# Altium schematic sheet Common_Conn.SchDoc(Common_Conn)
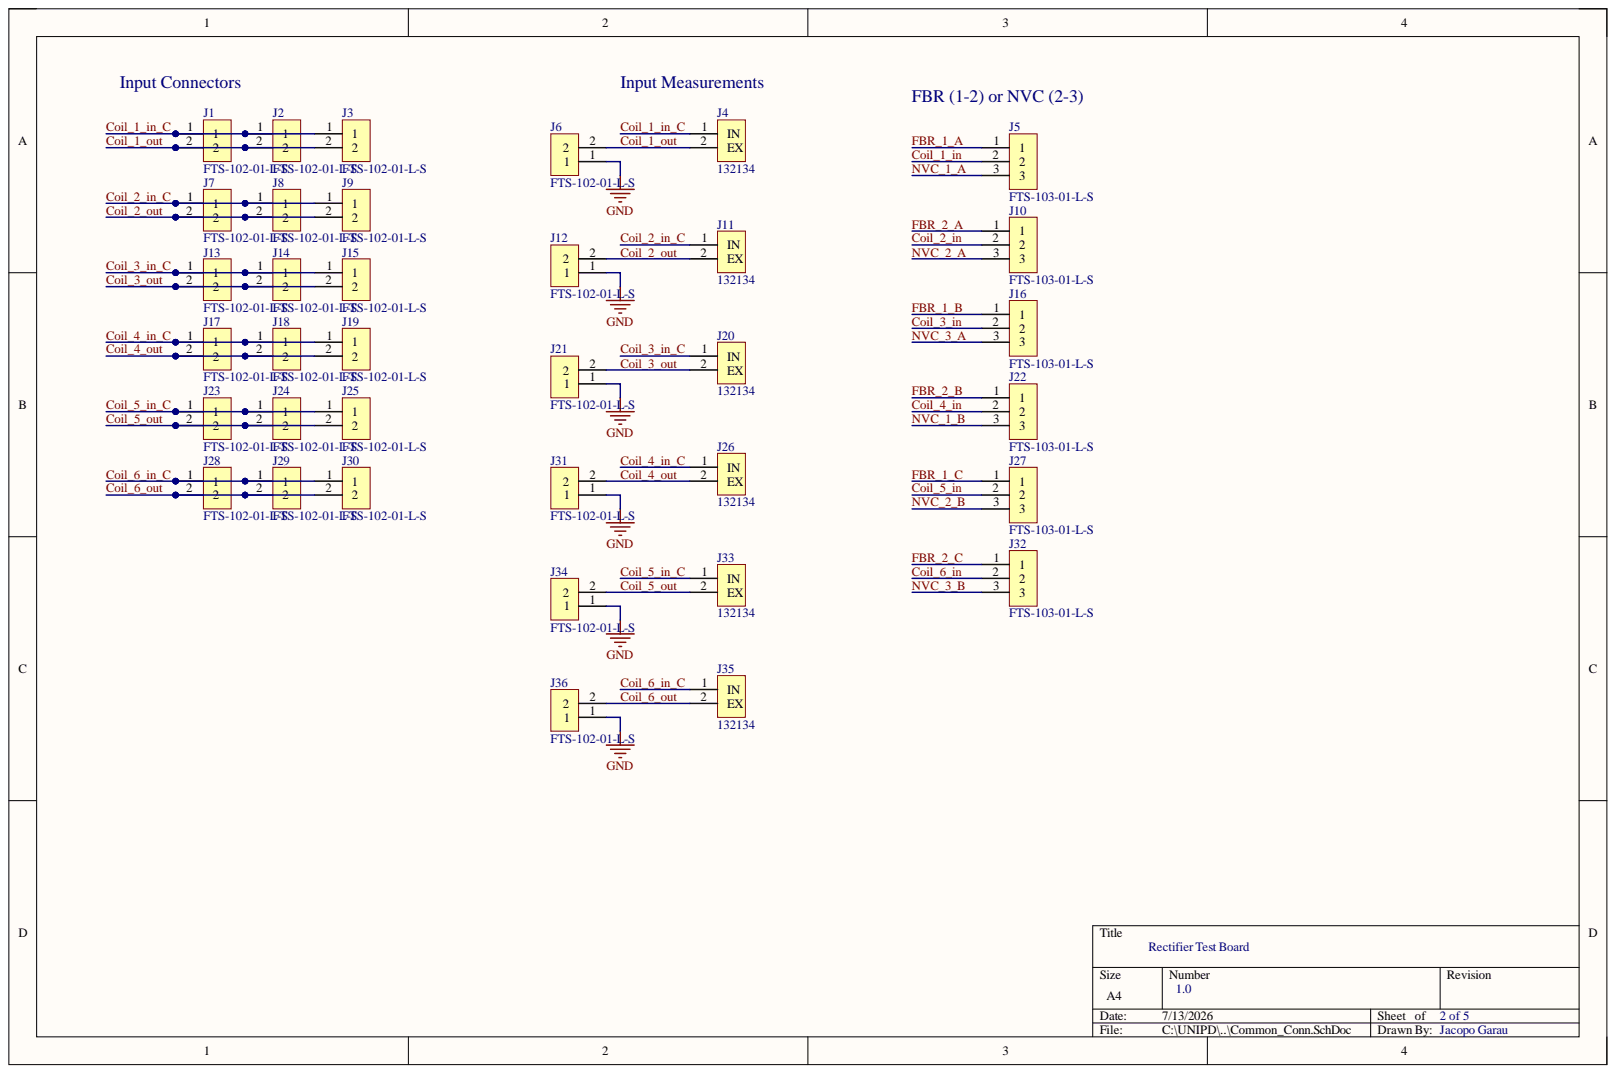
[diagram p0: Common_Conn.SchDoc]

## Parts
J1  FTS-102-01-L-S  [HDR_1x2_1.27mm]
J2  FTS-102-01-L-S  [HDR_1x2_1.27mm]
J3  FTS-102-01-L-S  [HDR_1x2_1.27mm]
J4  132134  [AMPHENOL_132134]
J5  FTS-103-01-L-S  [HDR_1x3_1.27mm]
J6  FTS-102-01-L-S  [HDR_1x2_1.27mm]
J7  FTS-102-01-L-S  [HDR_1x2_1.27mm]
J8  FTS-102-01-L-S  [HDR_1x2_1.27mm]
J9  FTS-102-01-L-S  [HDR_1x2_1.27mm]
J10  FTS-103-01-L-S  [HDR_1x3_1.27mm]
J11  132134  [AMPHENOL_132134]
J12  FTS-102-01-L-S  [HDR_1x2_1.27mm]
J13  FTS-102-01-L-S  [HDR_1x2_1.27mm]
J14  FTS-102-01-L-S  [HDR_1x2_1.27mm]
J15  FTS-102-01-L-S  [HDR_1x2_1.27mm]
J16  FTS-103-01-L-S  [HDR_1x3_1.27mm]
J17  FTS-102-01-L-S  [HDR_1x2_1.27mm]
J18  FTS-102-01-L-S  [HDR_1x2_1.27mm]
J19  FTS-102-01-L-S  [HDR_1x2_1.27mm]
J20  132134  [AMPHENOL_132134]
J21  FTS-102-01-L-S  [HDR_1x2_1.27mm]
J22  FTS-103-01-L-S  [HDR_1x3_1.27mm]
J23  FTS-102-01-L-S  [HDR_1x2_1.27mm]
J24  FTS-102-01-L-S  [HDR_1x2_1.27mm]
J25  FTS-102-01-L-S  [HDR_1x2_1.27mm]
J26  132134  [AMPHENOL_132134]
J27  FTS-103-01-L-S  [HDR_1x3_1.27mm]
J28  FTS-102-01-L-S  [HDR_1x2_1.27mm]
J29  FTS-102-01-L-S  [HDR_1x2_1.27mm]
J30  FTS-102-01-L-S  [HDR_1x2_1.27mm]
J31  FTS-102-01-L-S  [HDR_1x2_1.27mm]
J32  FTS-103-01-L-S  [HDR_1x3_1.27mm]
J33  132134  [AMPHENOL_132134]
J34  FTS-102-01-L-S  [HDR_1x2_1.27mm]
J35  132134  [AMPHENOL_132134]
J36  FTS-102-01-L-S  [HDR_1x2_1.27mm]

## Nets
GND: J6.1 J12.1 J21.1 J31.1 J34.1 J36.1
Coil_1_in: J5.2
Coil_1_in_C: J1.1 J2.1 J3.1 J4.1
Coil_1_out: J1.2 J2.2 J3.2 J4.2 J6.2
Coil_2_in: J10.2
Coil_2_in_C: J7.1 J8.1 J9.1 J11.1
Coil_2_out: J7.2 J8.2 J9.2 J11.2 J12.2
Coil_3_in: J16.2
Coil_3_in_C: J13.1 J14.1 J15.1 J20.1
Coil_3_out: J13.2 J14.2 J15.2 J20.2 J21.2
Coil_4_in: J22.2
Coil_4_in_C: J17.1 J18.1 J19.1 J26.1
Coil_4_out: J17.2 J18.2 J19.2 J26.2 J31.2
Coil_5_in: J27.2
Coil_5_in_C: J23.1 J24.1 J25.1 J33.1
Coil_5_out: J23.2 J24.2 J25.2 J33.2 J34.2
Coil_6_in: J32.2
Coil_6_in_C: J28.1 J29.1 J30.1 J35.1
Coil_6_out: J28.2 J29.2 J30.2 J35.2 J36.2
FBR_1_A: J5.1
FBR_1_B: J16.1
FBR_1_C: J27.1
FBR_2_A: J10.1
FBR_2_B: J22.1
FBR_2_C: J32.1
NVC_1_A: J5.3
NVC_1_B: J22.3
NVC_2_A: J10.3
NVC_2_B: J27.3
NVC_3_A: J16.3
NVC_3_B: J32.3

## Library data of the parts
J1-J3 J6-J9 J12-J15 J17-J19 J21 J23-J25 J28-J31 J34 J36 = FTS-102-01-L-S: CONN HEADER VERT 2POS 1.27MM; Manufacturer: Samtec; Manufacturer Lifecycle Status: PRODUCTION; Gender: Male; Number of Contacts: 2; Category: Headers and Wire Housings; Component Kind: Standard; ComponentLink1Description: https://sigma.octopart.com:443/165596534/technical_drawing/Samtec-FTS-102-01-L-S.pdf?src-supplier=JRH+Electronics; ComponentLink1URL: https://sigma.octopart.com:443/165596534/technical_drawing/Samtec-FTS-102-01-L-S.pdf?src-supplier=JRH+Electronics; ComponentLink2Description: https://datasheet.octopart.com:443/FTS-102-01-L-S-Samtec-datasheet-164323441.pdf?src-supplier=Upverter; ComponentLink2URL: https://datasheet.octopart.com:443/FTS-102-01-L-S-Samtec-datasheet-164323441.pdf?src-supplier=Upverter; ComponentLink3Description: https://sigma.octopart.com:443/97029285/technical_drawing/Samtec-FTS-102-01-L-S.pdf?src-supplier=Newark; ComponentLink3URL: https://sigma.octopart.com:443/97029285/technical_drawing/Samtec-FTS-102-01-L-S.pdf?src-supplier=Newark; ComponentLink4Description: https://datasheet.octopart.com:443/FTS-102-01-L-S-Samtec-datasheet-97029283.pdf?src-supplier=Samtec; ComponentLink4URL: https://datasheet.octopart.com:443/FTS-102-01-L-S-Samtec-datasheet-97029283.pdf?src-supplier=Samtec; ComponentLink5Description: https://datasheet.octopart.com:443/FTS-102-01-L-S-Samtec-datasheet-118493358.pdf?src-supplier=Electro+Sonic; ComponentLink5URL: https://datasheet.octopart.com:443/FTS-102-01-L-S-Samtec-datasheet-118493358.pdf?src-supplier=Electro+Sonic; ComponentLink6Description: https://datasheet.octopart.com:443/FTS-102-01-L-S-Samtec-datasheet-176663378.pdf?src-supplier=Toby+Electronics; ComponentLink6URL: https://datasheet.octopart.com:443/FTS-102-01-L-S-Samtec-datasheet-176663378.pdf?src-supplier=Toby+Electronics; ComponentLink7Description: https://datasheet.octopart.com:443/FTS-102-01-L-S-Samtec-datasheet-116535195.pdf?src-supplier=Toby+Electronics; ComponentLink7URL: https://datasheet.octopart.com:443/FTS-102-01-L-S-Samtec-datasheet-116535195.pdf?src-supplier=Toby+Electronics; ComponentLink8Description: https://datasheet.octopart.com:443/FTS-102-01-L-S-Samtec-datasheet-136395376.pdf?src-supplier=Toby+Electronics; ComponentLink8URL: https://datasheet.octopart.com:443/FTS-102-01-L-S-Samtec-datasheet-136395376.pdf?src-supplier=Toby+Electronics; ComponentLink9Description: https://datasheet.octopart.com:443/FTS-102-01-L-S-Samtec-datasheet-151470456.pdf?src-supplier=Sager+Electronics; ComponentLink9URL: https://datasheet.octopart.com:443/FTS-102-01-L-S-Samtec-datasheet-151470456.pdf?src-supplier=Sager+Electronics; Contact Material: Gold, Nickel; Contact Plating: Gold; Height: 4.17mm; Housing Color: Black; Housing Material: Polymer; Length: 2.54mm; Library Name: Schlib.SchLib; Lifecycle Status: Production; Manufacturer Part Number: FTS-102-01-L-S; Max Operating Temperature: 125°C; Min Operating Temperature: -55°C; Number of Rows: 2; Orientation: Straight; Packaging: Bulk; Pitch: 1.27mm; Pricing: 1=0.57, 50=0.496, 100=0.42, 500=0.35, 1000=0.299, 2000=0.244, 5000=0.24223 (USD); Radiation Hardening: No; RoHS: Compliant; Stock: 1509; Supplier: DigiKey; Supplier Part Number: FTS-102-01-L-S-ND; Termination: Solder
J4 J11 J20 J26 J33 J35 = 132134: connector, rf coaxial, sma str jack for p.c.board, 4 legs, .050 center cont, 50 ohm; Manufacturer: Amphenol RF; Body Material: Brass; Body Plating: Gold; Clock Frequency: 18GHz; Connector Type: Coaxial, SMA, Socket; Dielectric Material: PTFE; Impedance: 50Ω; Number of Contacts: 1; Case/Package: SMA; Category: RF / Coaxial Connectors; Component Kind: Standard; ComponentLink1Description: https://datasheet.octopart.com:443/132134-Amphenol-RF-datasheet-178922999.pdf?src-supplier=ODG+(Origin+Data+Global); ComponentLink1URL: https://datasheet.octopart.com:443/132134-Amphenol-RF-datasheet-178922999.pdf?src-supplier=ODG+(Origin+Data+Global); ComponentLink2Description: https://datasheet.octopart.com:443/132134-Amphenol-RF-datasheet-11478678.pdf?src-supplier=TME; ComponentLink2URL: https://datasheet.octopart.com:443/132134-Amphenol-RF-datasheet-11478678.pdf?src-supplier=TME; ComponentLink3Description: https://datasheet.octopart.com:443/132134-Amphenol-RF-datasheet-21190506.pdf?src-supplier=element14+APAC; ComponentLink3URL: https://datasheet.octopart.com:443/132134-Amphenol-RF-datasheet-21190506.pdf?src-supplier=element14+APAC; ComponentLink4Description: https://datasheet.octopart.com:443/132134-Amphenol-datasheet-8508134.pdf?src-supplier=element14+APAC; ComponentLink4URL: https://datasheet.octopart.com:443/132134-Amphenol-datasheet-8508134.pdf?src-supplier=element14+APAC; ComponentLink5Description: https://datasheet.octopart.com:443/132134-Amphenol-RF-datasheet-48840.pdf?src-supplier=RS+(Formerly+Allied+Electronics); ComponentLink5URL: https://datasheet.octopart.com:443/132134-Amphenol-RF-datasheet-48840.pdf?src-supplier=RS+(Formerly+Allied+Electronics); ComponentLink6Description: https://datasheet.octopart.com:443/132134-Amphenol-datasheet-16831.pdf?src-supplier=DigiKey; ComponentLink6URL: https://datasheet.octopart.com:443/132134-Amphenol-datasheet-16831.pdf?src-supplier=DigiKey; ComponentLink7Description: https://datasheet.octopart.com:443/132134-Amphenol-Connex-datasheet-31974814.pdf?src-supplier=East+Coast+Microwave; ComponentLink7URL: https://datasheet.octopart.com:443/132134-Amphenol-Connex-datasheet-31974814.pdf?src-supplier=East+Coast+Microwave; ComponentLink8Description: https://datasheet.octopart.com:443/132134-Amphenol-Connex-datasheet-32030771.pdf?src-supplier=East+Coast+Microwave; ComponentLink8URL: https://datasheet.octopart.com:443/132134-Amphenol-Connex-datasheet-32030771.pdf?src-supplier=East+Coast+Microwave; ComponentLink9Description: https://datasheet.octopart.com:443/132134-Amphenol-RF-datasheet-177010530.pdf?src-supplier=Burklin+Elektronik; ComponentLink9URL: https://datasheet.octopart.com:443/132134-Amphenol-RF-datasheet-177010530.pdf?src-supplier=Burklin+Elektronik; ComponentLink10Description: https://datasheet.octopart.com:443/132134-Amphenol-datasheet-7088102.pdf?src-supplier=Jameco; ComponentLink10URL: https://datasheet.octopart.com:443/132134-Amphenol-datasheet-7088102.pdf?src-supplier=Jameco; Contact Gender: Female; Contact Material: Gold; Contact Plating: Gold; Country of Origin: Vietnam; Depth: 7mm; Export Control Classification Number (ECCN) Code: EAR99; Fastening Type: Threaded; Frequency: 18GHz; Harmonized Tariff Schedule (HTS) Code: 8536.69.40.10; Height: 9.73mm; Housing Color: Gold; Insulation Material: Teflon; Introduction Date: 2001-11-27; Lead Free: Lead Free; Length: 7mm; Library Name: Schlib.SchLib; Lifecycle Status: Production; Manufacturer Part Number: 132134; Max Operating Temperature: 165°C; Min Operating Temperature: -65°C; Mounting Technology: PCB, Through Hole; Number of Ports: 1; Orientation: Straight; Packaging: Bulk; Pins: 1; Pricing: 1=6.97, 10=5.922, 25=5.5508, 50=5.2856, 100=5.0333, 300=4.65793, 500=4.49316, 1000=4.27889 (USD); Radiation Hardening: No; REACH SVHC: No; RoHS: Compliant; Shell Material: Brass; Stock: 22451; Supplier: DigiKey; Supplier Part Number: ACX1230-ND; Termination: Solder; Weight: 1.000001g
J5 J10 J16 J22 J27 J32 = FTS-103-01-L-S: CONN HEADER VERT 3POS 1.27MM; Manufacturer: Samtec; Manufacturer Lifecycle Status: PRODUCTION; Gender: Male; Number of Contacts: 3; Category: Board to Board Connectors; Component Kind: Standard; ComponentLink1Description: https://datasheet.octopart.com:443/FTS-103-01-L-S-Samtec-datasheet-97029283.pdf?src-supplier=Newark; ComponentLink1URL: https://datasheet.octopart.com:443/FTS-103-01-L-S-Samtec-datasheet-97029283.pdf?src-supplier=Newark; ComponentLink2Description: https://sigma.octopart.com:443/97029285/technical_drawing/Samtec-FTS-103-01-L-S.pdf?src-supplier=Newark; ComponentLink2URL: https://sigma.octopart.com:443/97029285/technical_drawing/Samtec-FTS-103-01-L-S.pdf?src-supplier=Newark; ComponentLink3Description: https://datasheet.octopart.com:443/FTS-103-01-L-S-Samtec-datasheet-118493358.pdf?src-supplier=Electro+Sonic; ComponentLink3URL: https://datasheet.octopart.com:443/FTS-103-01-L-S-Samtec-datasheet-118493358.pdf?src-supplier=Electro+Sonic; ComponentLink4Description: https://datasheet.octopart.com:443/FTS-103-01-L-S-Samtec-datasheet-165596534.pdf?src-supplier=JRH+Electronics; ComponentLink4URL: https://datasheet.octopart.com:443/FTS-103-01-L-S-Samtec-datasheet-165596534.pdf?src-supplier=JRH+Electronics; ComponentLink5Description: https://datasheet.octopart.com:443/FTS-103-01-L-S-Samtec-datasheet-176663378.pdf?src-supplier=Toby+Electronics; ComponentLink5URL: https://datasheet.octopart.com:443/FTS-103-01-L-S-Samtec-datasheet-176663378.pdf?src-supplier=Toby+Electronics; ComponentLink6Description: https://datasheet.octopart.com:443/FTS-103-01-L-S-Samtec-datasheet-116535195.pdf?src-supplier=Toby+Electronics; ComponentLink6URL: https://datasheet.octopart.com:443/FTS-103-01-L-S-Samtec-datasheet-116535195.pdf?src-supplier=Toby+Electronics; ComponentLink7Description: https://datasheet.octopart.com:443/FTS-103-01-L-S-Samtec-datasheet-136395376.pdf?src-supplier=Toby+Electronics; ComponentLink7URL: https://datasheet.octopart.com:443/FTS-103-01-L-S-Samtec-datasheet-136395376.pdf?src-supplier=Toby+Electronics; ComponentLink8Description: https://datasheet.octopart.com:443/FTS-103-01-L-S-Samtec-datasheet-151470456.pdf?src-supplier=Sager+Electronics; ComponentLink8URL: https://datasheet.octopart.com:443/FTS-103-01-L-S-Samtec-datasheet-151470456.pdf?src-supplier=Sager+Electronics; Contact Plating: Gold; Library Name: Schlib.SchLib; Lifecycle Status: Production; Manufacturer Part Number: FTS-103-01-L-S; Mounting Technology: Through Hole; Number of Rows: 1; Packaging: Bulk; Pitch: 1.27mm; Pricing: 1=0.85, 50=0.744, 100=0.631, 500=0.524, 1000=0.448, 2000=0.36601, 5000=0.34448 (USD); Radiation Hardening: No; RoHS: Compliant; Stock: 1520; Supplier: DigiKey; Supplier Part Number: SAM15177-ND; Termination: Solder
